# Altium schematic sheet UserInterface.SchDoc(UserInterface)
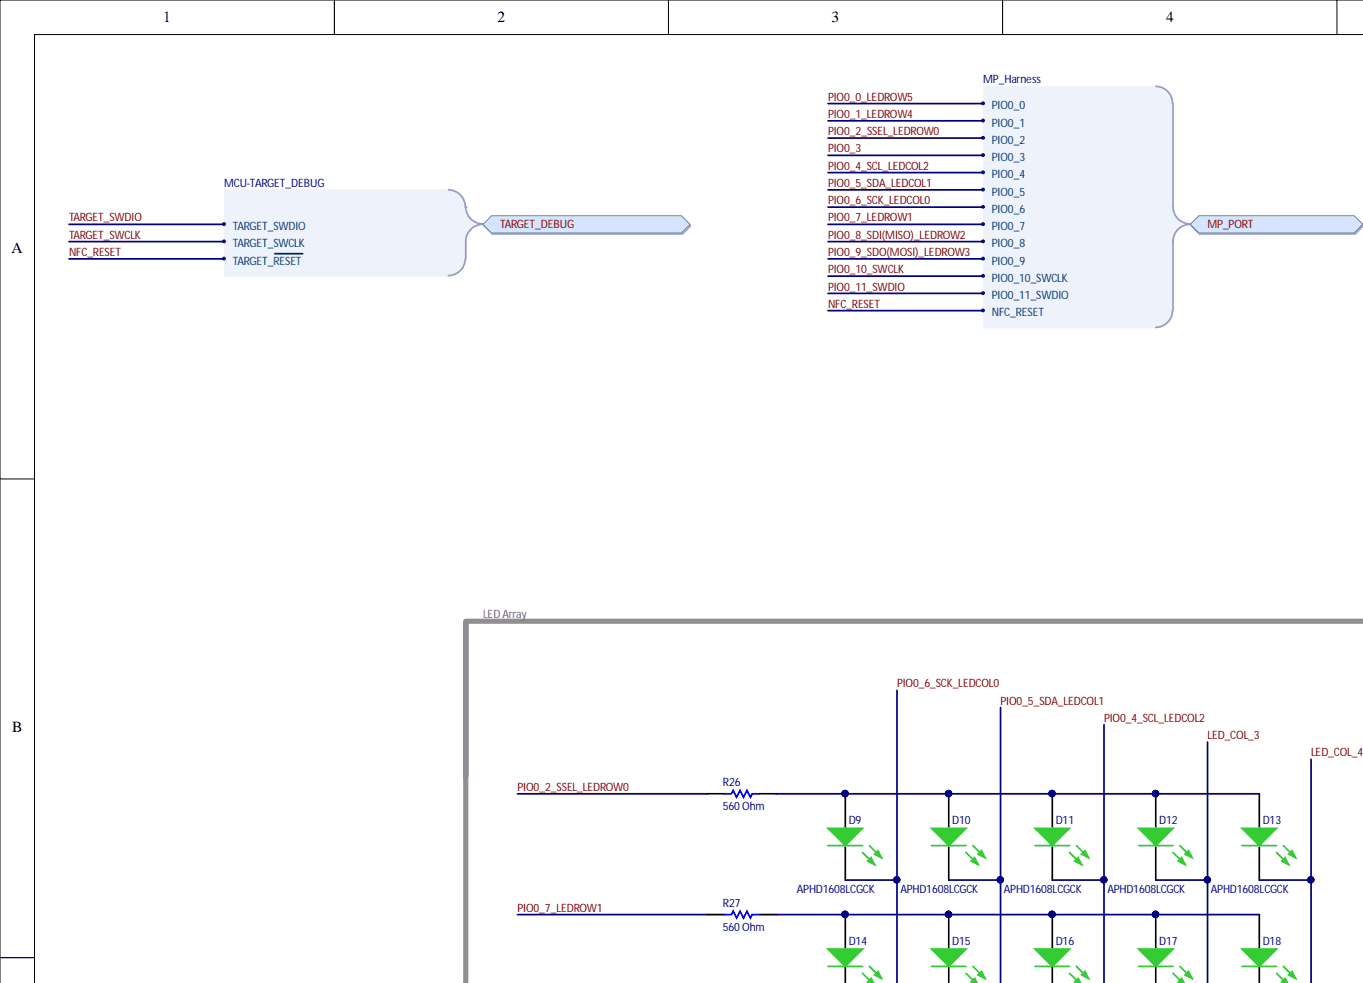
[diagram p0: UserInterface.SchDoc, top left]
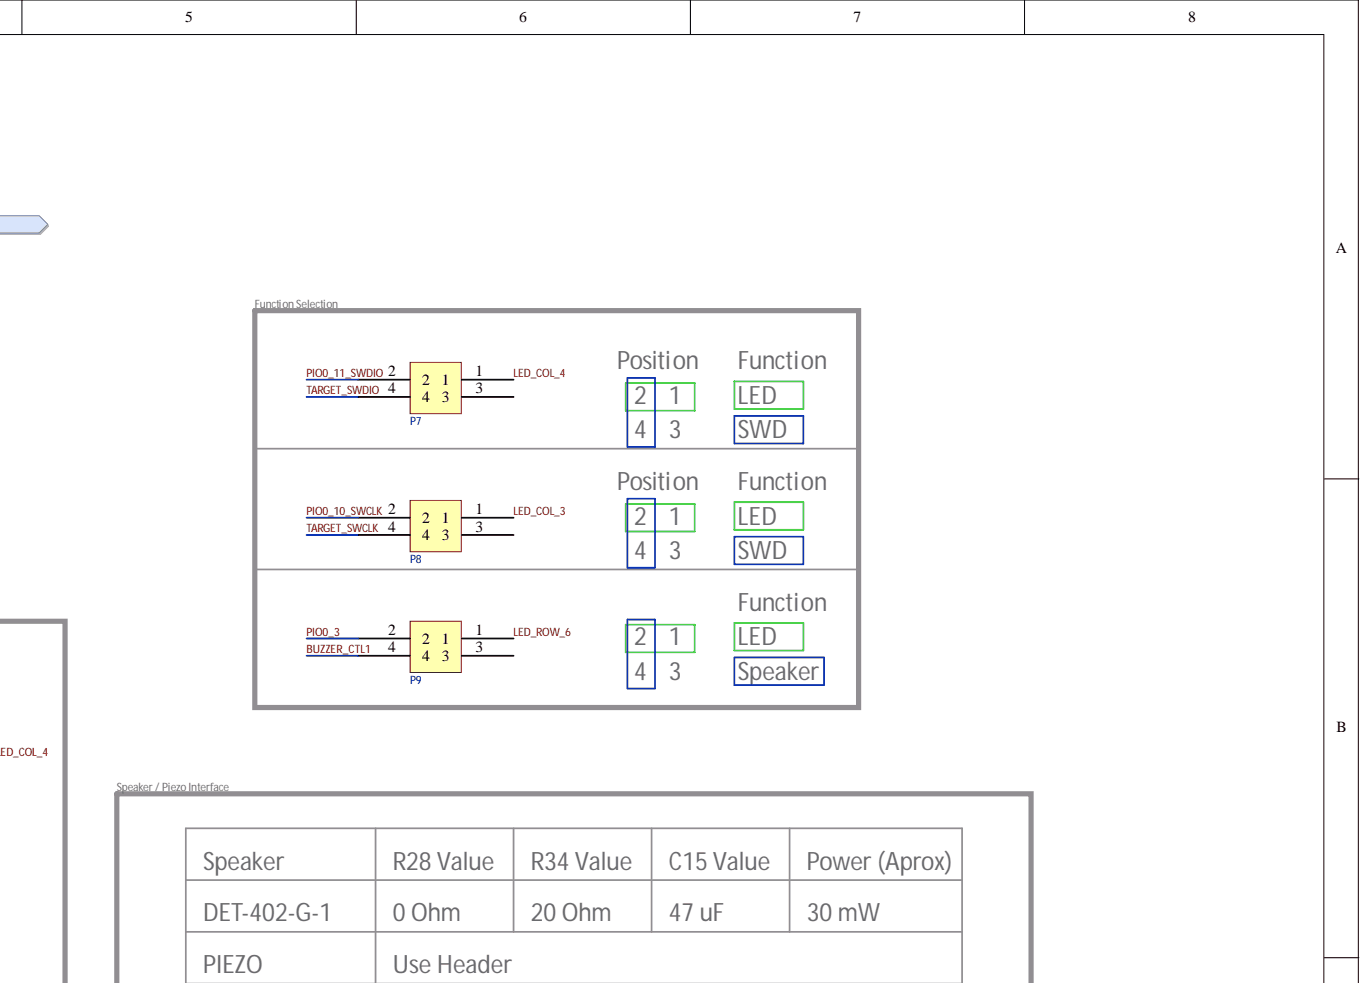
[diagram p1: UserInterface.SchDoc, top right]
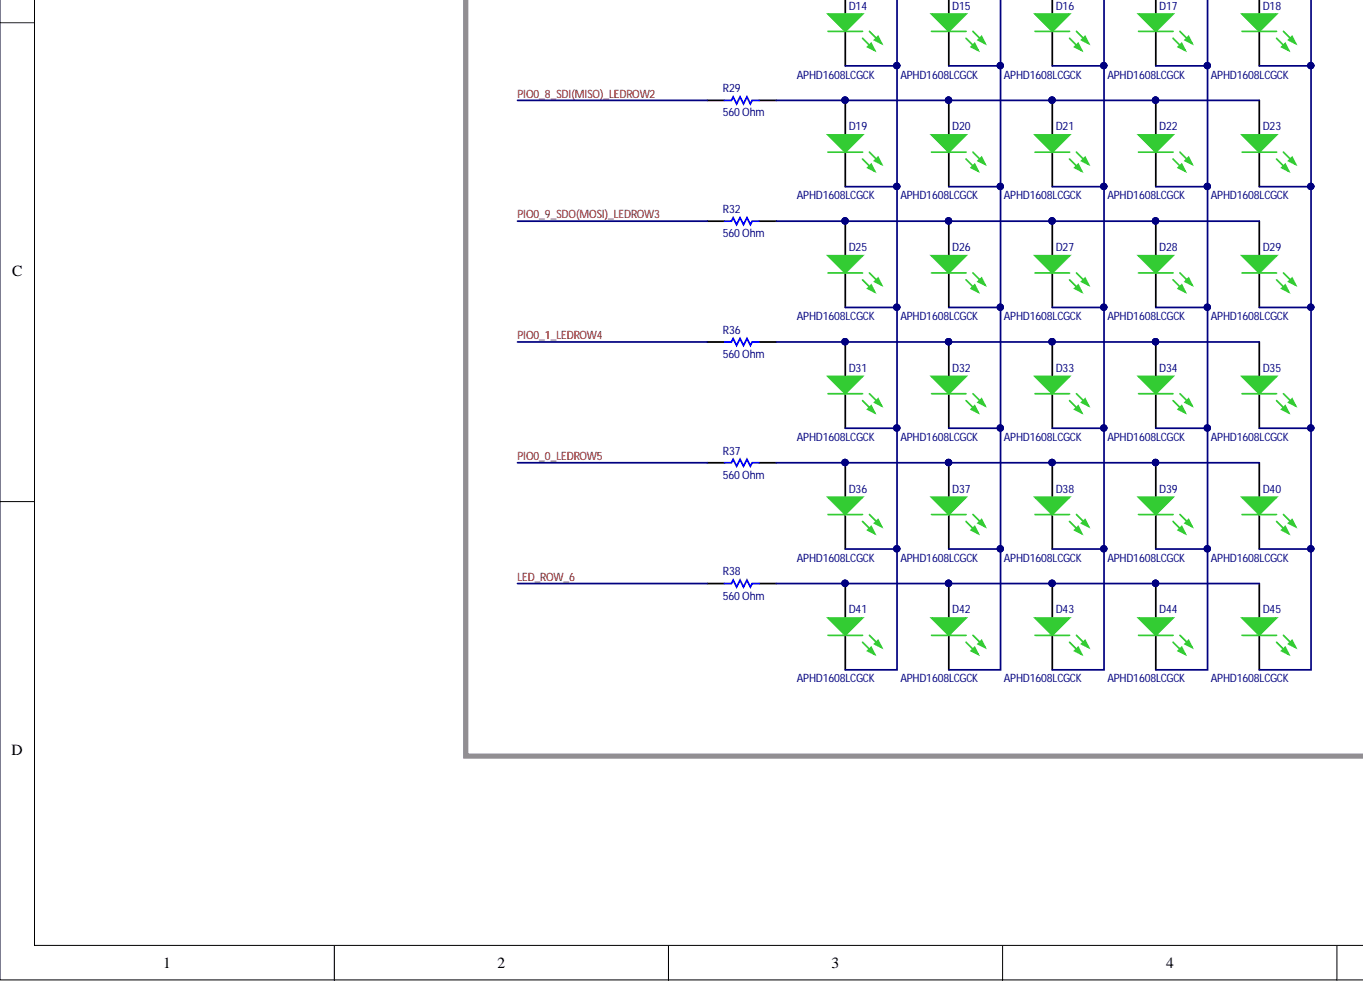
[diagram p2: UserInterface.SchDoc, bottom left]
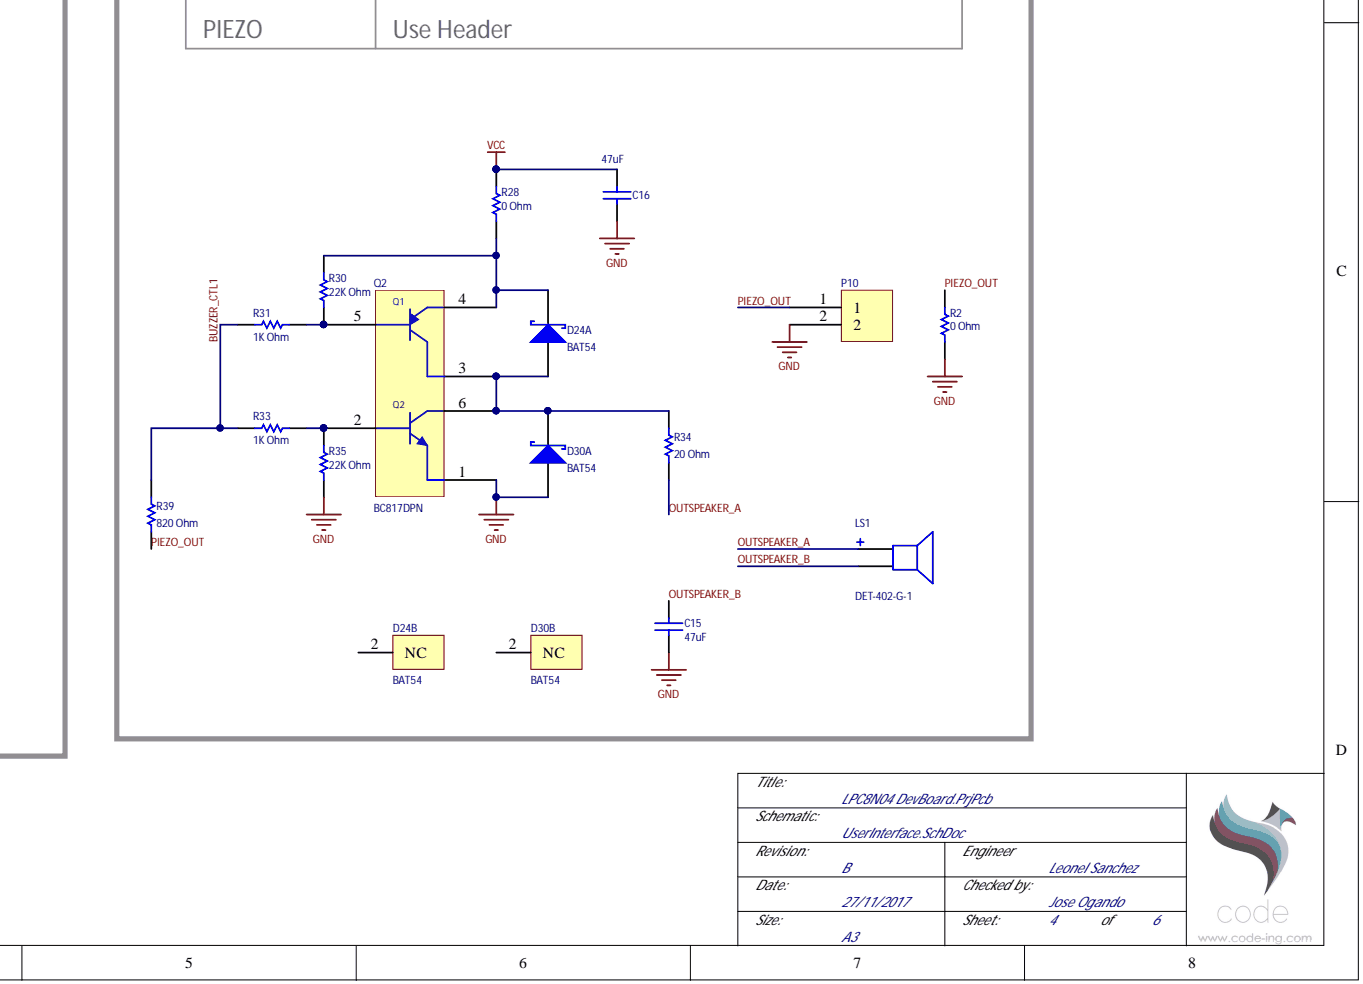
[diagram p3: UserInterface.SchDoc, bottom right]

## Parts
C15  value 47uF  [CAPC0805(2012)145_N]  (hidden comment: CAP 47uF 6.3V 0805(2012))
C16  value 47uF  [CAPC0805(2012)145_N]  (hidden comment: CAP 47uF 6.3V 0805(2012))
D9  APHD1608LCGCK  [1.6X.8 SMT LED 0603]
D10  APHD1608LCGCK  [1.6X.8 SMT LED 0603]
D11  APHD1608LCGCK  [1.6X.8 SMT LED 0603]
D12  APHD1608LCGCK  [1.6X.8 SMT LED 0603]
D13  APHD1608LCGCK  [1.6X.8 SMT LED 0603]
D14  APHD1608LCGCK  [1.6X.8 SMT LED 0603]
D15  APHD1608LCGCK  [1.6X.8 SMT LED 0603]
D16  APHD1608LCGCK  [1.6X.8 SMT LED 0603]
D17  APHD1608LCGCK  [1.6X.8 SMT LED 0603]
D18  APHD1608LCGCK  [1.6X.8 SMT LED 0603]
D19  APHD1608LCGCK  [1.6X.8 SMT LED 0603]
D20  APHD1608LCGCK  [1.6X.8 SMT LED 0603]
D21  APHD1608LCGCK  [1.6X.8 SMT LED 0603]
D22  APHD1608LCGCK  [1.6X.8 SMT LED 0603]
D23  APHD1608LCGCK  [1.6X.8 SMT LED 0603]
D24  BAT54  [NXP-SOT23-3_V]  (parts A,B)
D25  APHD1608LCGCK  [1.6X.8 SMT LED 0603]
D26  APHD1608LCGCK  [1.6X.8 SMT LED 0603]
D27  APHD1608LCGCK  [1.6X.8 SMT LED 0603]
D28  APHD1608LCGCK  [1.6X.8 SMT LED 0603]
D29  APHD1608LCGCK  [1.6X.8 SMT LED 0603]
D30  BAT54  [NXP-SOT23-3_V]  (parts A,B)
D31  APHD1608LCGCK  [1.6X.8 SMT LED 0603]
D32  APHD1608LCGCK  [1.6X.8 SMT LED 0603]
D33  APHD1608LCGCK  [1.6X.8 SMT LED 0603]
D34  APHD1608LCGCK  [1.6X.8 SMT LED 0603]
D35  APHD1608LCGCK  [1.6X.8 SMT LED 0603]
D36  APHD1608LCGCK  [1.6X.8 SMT LED 0603]
D37  APHD1608LCGCK  [1.6X.8 SMT LED 0603]
D38  APHD1608LCGCK  [1.6X.8 SMT LED 0603]
D39  APHD1608LCGCK  [1.6X.8 SMT LED 0603]
D40  APHD1608LCGCK  [1.6X.8 SMT LED 0603]
D41  APHD1608LCGCK  [1.6X.8 SMT LED 0603]
D42  APHD1608LCGCK  [1.6X.8 SMT LED 0603]
D43  APHD1608LCGCK  [1.6X.8 SMT LED 0603]
D44  APHD1608LCGCK  [1.6X.8 SMT LED 0603]
D45  APHD1608LCGCK  [1.6X.8 SMT LED 0603]
LS1  DET-402-G-1  [DET-402-G-1]
P7  Header 2x2 0.05'' PTH  [Header 2x2 0.050 pitch]  (value not drawn)
P8  Header 2x2 0.05'' PTH  [Header 2x2 0.050 pitch]  (value not drawn)
P9  Header 2x2 0.05'' PTH  [Header 2x2 0.050 pitch]  (value not drawn)
P10  Header 2x1 0.05'' PTH  [Header 1x2 0.050 pitch]  (value not drawn)
Q2  BC817DPN  [SOT-457]
R2  value 0 Ohm  [RESC0402(1005)_N]  (hidden comment: Jumper 0402(1005))
R26  value 560 Ohm  [RESC0402(1005)_N]  (hidden comment: 560R 5% 0402(1005))
R27  value 560 Ohm  [RESC0402(1005)_N]  (hidden comment: 560R 5% 0402(1005))
R28  value 0 Ohm  [RESC0402(1005)_N]  (hidden comment: Jumper 0402(1005))
R29  value 560 Ohm  [RESC0402(1005)_N]  (hidden comment: 560R 5% 0402(1005))
R30  value 22K Ohm  [RESC0402(1005)_N]  (hidden comment: 22K 5% 0402(1005))
R31  value 1K Ohm  [RESC0402(1005)_N]  (hidden comment: 1K 5% 0402(1005))
R32  value 560 Ohm  [RESC0402(1005)_N]  (hidden comment: 560R 5% 0402(1005))
R33  value 1K Ohm  [RESC0402(1005)_N]  (hidden comment: 1K 5% 0402(1005))
R34  value 20 Ohm  [RESC0402(1005)_N]  (hidden comment: 20R 5% 0402(1005))
R35  value 22K Ohm  [RESC0402(1005)_N]  (hidden comment: 22K 5% 0402(1005))
R36  value 560 Ohm  [RESC0402(1005)_N]  (hidden comment: 560R 5% 0402(1005))
R37  value 560 Ohm  [RESC0402(1005)_N]  (hidden comment: 560R 5% 0402(1005))
R38  value 560 Ohm  [RESC0402(1005)_N]  (hidden comment: 560R 5% 0402(1005))
R39  value 820 Ohm  [RESC0402(1005)_N]  (hidden comment: 820R 1% 0402(1005))

## Ports
MP_PORT, MP_PORT.NFC_RESET, MP_PORT.PIO0_0, MP_PORT.PIO0_1, MP_PORT.PIO0_2, MP_PORT.PIO0_3, MP_PORT.PIO0_4, MP_PORT.PIO0_5, MP_PORT.PIO0_6, MP_PORT.PIO0_7, MP_PORT.PIO0_8, MP_PORT.PIO0_9, MP_PORT.PIO0_10_SWCLK, MP_PORT.PIO0_11_SWDIO, TARGET_DEBUG, TARGET_DEBUG.TARGET_SWCLK, TARGET_DEBUG.TARGET_SWDIO, TARGET_DEBUG.TARGET_~{RESET}

## Nets
GND: C15.1 C16.1 D30.1 P10.2 Q2.1 R2.1 R35.1
VCC: C16.2 R28.2
BUZZER_CTL1: P9.4 R31.1 R33.1 R39.1
LED_COL_3: D12.2 D17.2 D22.2 D28.2 D34.2 D39.2 D44.2 P8.1
LED_COL_4: D13.2 D18.2 D23.2 D29.2 D35.2 D40.2 D45.2 P7.1
LED_ROW_6: P9.1 R38.1
OUTSPEAKER_A: LS1.1 R34.1
OUTSPEAKER_B: C15.2 LS1.2
PIEZO_OUT: P10.1 R2.2 R39.2
PIO0_0_LEDROW5: R37.1 ; port MP_PORT
PIO0_1_LEDROW4: R36.1 ; port MP_PORT
PIO0_2_SSEL_LEDROW0: R26.1 ; port MP_PORT
PIO0_3: P9.2 ; port MP_PORT
PIO0_4_SCL_LEDCOL2: D11.2 D16.2 D21.2 D27.2 D33.2 D38.2 D43.2 ; port MP_PORT
PIO0_5_SDA_LEDCOL1: D10.2 D15.2 D20.2 D26.2 D32.2 D37.2 D42.2 ; port MP_PORT
PIO0_6_SCK_LEDCOL0: D9.2 D14.2 D19.2 D25.2 D31.2 D36.2 D41.2 ; port MP_PORT
PIO0_7_LEDROW1: R27.1 ; port MP_PORT
PIO0_8_SDI(MISO)_LEDROW2: R29.1 ; port MP_PORT
PIO0_9_SDO(MOSI)_LEDROW3: R32.1 ; port MP_PORT
PIO0_10_SWCLK: P8.2 ; port MP_PORT
PIO0_11_SWDIO: P7.2 ; port MP_PORT
TARGET_SWCLK: P8.4 ; port TARGET_DEBUG
TARGET_SWDIO: P7.4 ; port TARGET_DEBUG
NetD9_1: D9.1 D10.1 D11.1 D12.1 D13.1 R26.2
NetD14_1: D14.1 D15.1 D16.1 D17.1 D18.1 R27.2
NetD19_1: D19.1 D20.1 D21.1 D22.1 D23.1 R29.2
NetD24_1: D24.1 D30.3 Q2.3 Q2.6 R34.2
NetD24_3: D24.3 Q2.4 R28.1 R30.2
NetD25_1: D25.1 D26.1 D27.1 D28.1 D29.1 R32.2
NetD31_1: D31.1 D32.1 D33.1 D34.1 D35.1 R36.2
NetD36_1: D36.1 D37.1 D38.1 D39.1 D40.1 R37.2
NetD41_1: D41.1 D42.1 D43.1 D44.1 D45.1 R38.2
NetQ2_2: Q2.2 R33.2 R35.2
NetQ2_5: Q2.5 R30.1 R31.2
NFC_RESET: ; port MP_PORT, port TARGET_DEBUG

Not connected: D24 2(NC); D30 2(NC); P7 3; P8 3; P9 3

## Library data of the parts
C15 C16 = CMP-1036-04950-1: CAP 47uF 6.3V ±20% 0805 (2012 Metric) Thickness 1.45mm SMD; Tolerance: Â±20%; Case-Metric: 2012; Case-EIA: 0805; Technology: SMT; Rated Voltage: 6.3 V; Max Thickness: 1.45 mm; Library Name: Altium Content Vault; Component Kind: Standard
D9-D23 D25-D29 D31-D45 = APHD1608LCGCK: Green 570nm LED Indication - Discrete 1.9V 0603 (1608 Metric); Manufacturer: KingBright; Manufacturer part #: APHD1608LCGCK; Library Name: LED Indication - Discrete.SchLib; Creation date: 3-Oct-2017; Component Kind: Standard; Created by: Jose
D24 D30 = CMP-0417-00004-1: Schottky Barrier Diode, 30 V, 2 uA, -55 to 150 degC, 3-Pin SOT23, RoHS, Tape and Reel; Manufacturer: NXP Semiconductors; VR (V): 30; VF (mV): 800; Trr (ns): 5; Rth(j-a) (K/W): 500; IR (uA): 2; Cd (pF): 10; Temperature Range: -55 to 150 degC; RoHS: TRUE; PartNumber: BAT54; Packing: Tape and Reel; PackageReference: SOT23-3; PackageDescription: 3-Pin Plastic Surface-mounted Package, Body 1.3 x 2.9 mm, Pitch 0.95 mm; Mounting Technology: Surface Mount; Library Name: Altium Content Vault; DatasheetVersion: Rev. 5; ComponentLink2URL: http://www.nxp.com/documents/data_sheet/BAT54_SER.pdf; ComponentLink2Description: Datasheet; ComponentLink1URL: http://www.nxp.com/; ComponentLink1Description: Manufacturer URL; Component Kind: Standard
LS1 = DET-402-G-1: Speaker, Surface Mount, 3x4.5mm; Manufacturer: AAC; Manufacturer part #: DET-402-G-1; Creation date: 11-Sept-2017; Component Kind: Standard; Library Name: Speakers.IntLib; Created by: Jose
P7-P9 = Header 2x2 0.05'' PTH: CONN HEADER .050" 4POS DL PCB AU; Manufacturer Part #: GRPB022VWVN-RC; Manufacturer: Sullins Connector Solutions; Creation Date: 7-Sept-2017; Component Kind: Standard; Library Name: CODE Miscellaneous Connectors.IntLib; Created By: Jose
P10 = Header 2x1 0.05'' PTH: CONN HEADER .050" 4POS DL PCB AU; Manufacturer Part #: GRPB021VWVN-RC; Manufacturer: Sullins Connector Solutions; Creation Date: 7-Sept-2017; Component Kind: Standard; Library Name: CODE Miscellaneous Connectors.IntLib; Created By: Jose
Q2 = BC817DPN: TRANS NPN/PNP 45V 0.5A 6TSOP; Manufacturer: Nexperia; Manufacturer Part #: BC817DPN; Creation Date: 5-Sept-2017; Component Kind: Standard; Library Name: Transistors - Bipolar (BJT) - Arrays.IntLib; Created by: Jose
R2 R28 = CMP-1011-00001-1: Jumper 0402 (1005 Metric); Tolerance: None; Case-Metric: 1005; Case-EIA: 0402; Technology: SMT; Power: None; Library Name: Altium Content Vault; Component Kind: Standard
R26 R27 R29 R32 R36-R38 = CMP-1011-00068-1: 560R 0.063W 5% 0402 (1005 Metric)  SMD; Case-Metric: 1005; Case-EIA: 0402; Tolerance: 5 %; Technology: SMT; Power: 0.063 W; Library Name: Altium Content Vault; Component Kind: Standard
R30 R35 = CMP-1011-00106-1: 22K 0.063W 5% 0402 (1005 Metric)  SMD; Case-Metric: 1005; Case-EIA: 0402; Tolerance: 5 %; Technology: SMT; Power: 0.063 W; Library Name: Altium Content Vault; Component Kind: Standard
R31 R33 = CMP-1011-00074-1: 1K 0.063W 5% 0402 (1005 Metric)  SMD; Case-Metric: 1005; Case-EIA: 0402; Tolerance: 5 %; Technology: SMT; Power: 0.063 W; Library Name: Altium Content Vault; Component Kind: Standard
R34 = CMP-1011-00033-1: 20R 0.063W 5% 0402 (1005 Metric)  SMD; Case-Metric: 1005; Case-EIA: 0402; Tolerance: 5 %; Technology: SMT; Power: 0.063 W; Library Name: Altium Content Vault; Component Kind: Standard
R39 = CMP-1011-00500-1: 820R 0.063W 1% 0402 (1005 Metric)  SMD; Case-Metric: 1005; Case-EIA: 0402; Tolerance: 1 %; Technology: SMT; Power: 0.063 W; Library Name: Altium Content Vault; Component Kind: Standard
